# Altium schematic sheet km_kl10_fuse.SchDoc
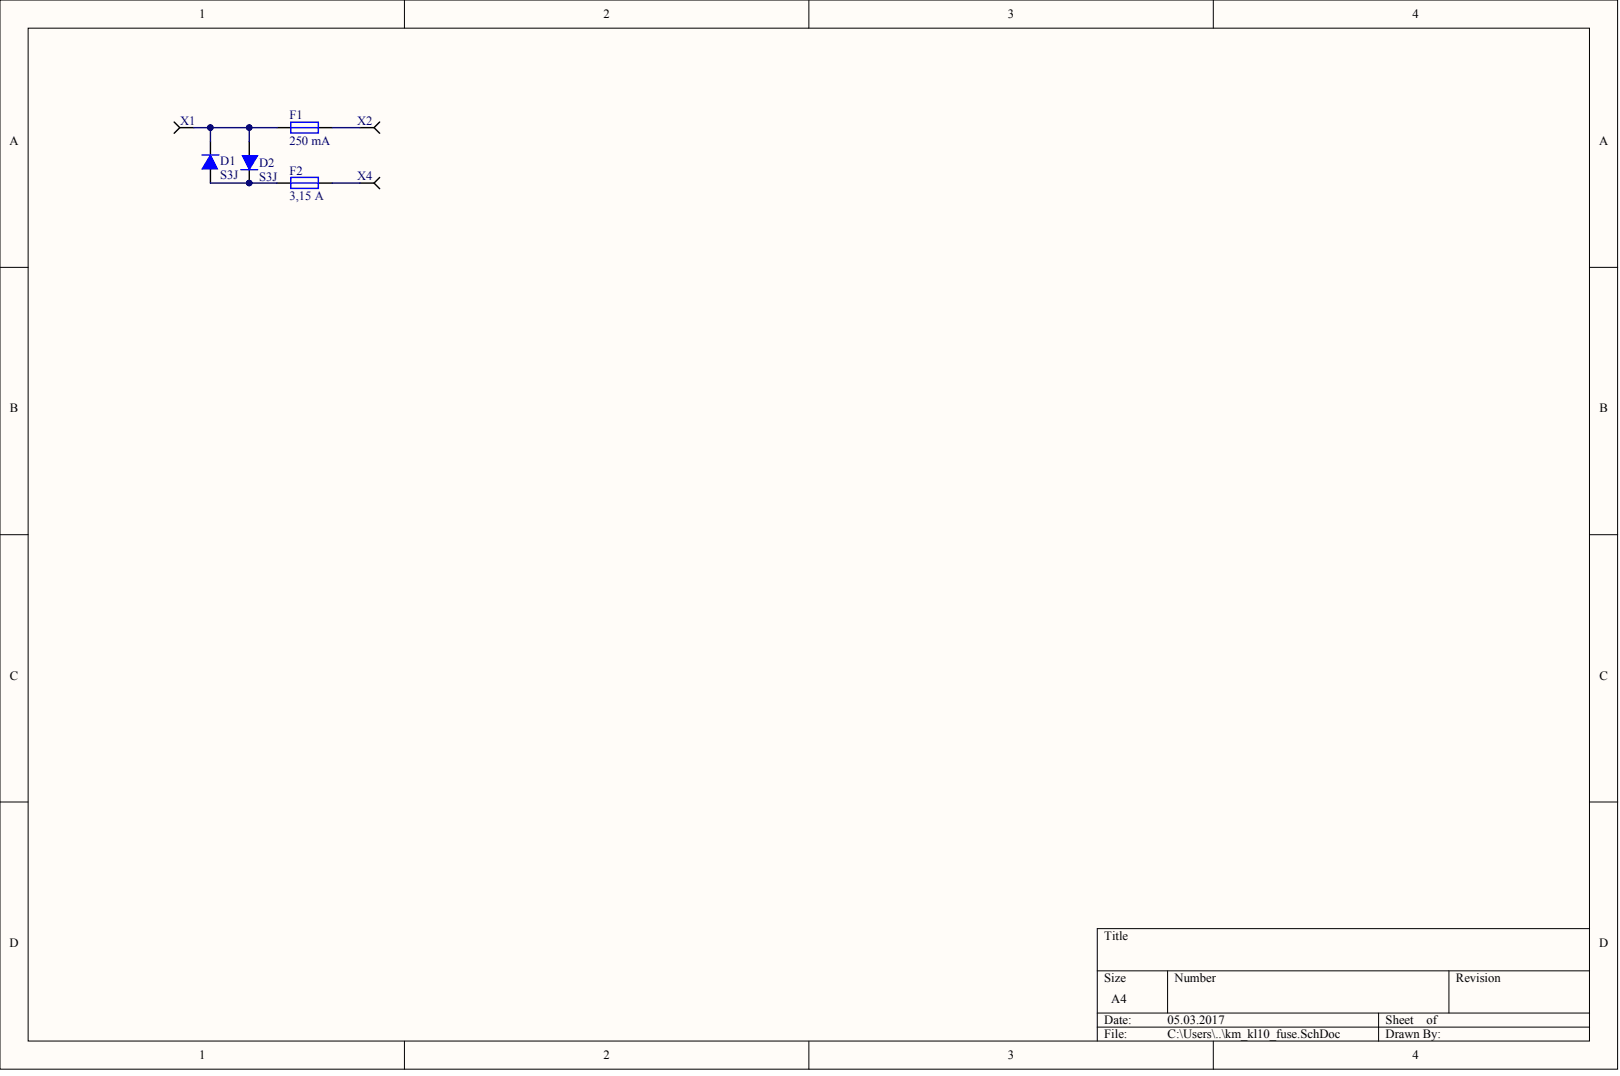
[diagram p0: km_kl10_fuse.SchDoc]

## Parts
D1  S3J
D2  S3J
F1  250 mA
F2  3,15 A
X1  Conn_1  (value not drawn)
X2  Conn_1  (value not drawn)
X4  Conn_1  (value not drawn)

## Nets
NetD1_1: D1.1 D2.2 F1.1 X1.1
NetD1_2: D1.2 D2.1 F2.1
NetF1_2: F1.2 X2.1
NetF2_2: F2.2 X4.1
